# Altium schematic sheet D_SUB CONNECTOR.SchDoc("D_SUB CONNECTOR")
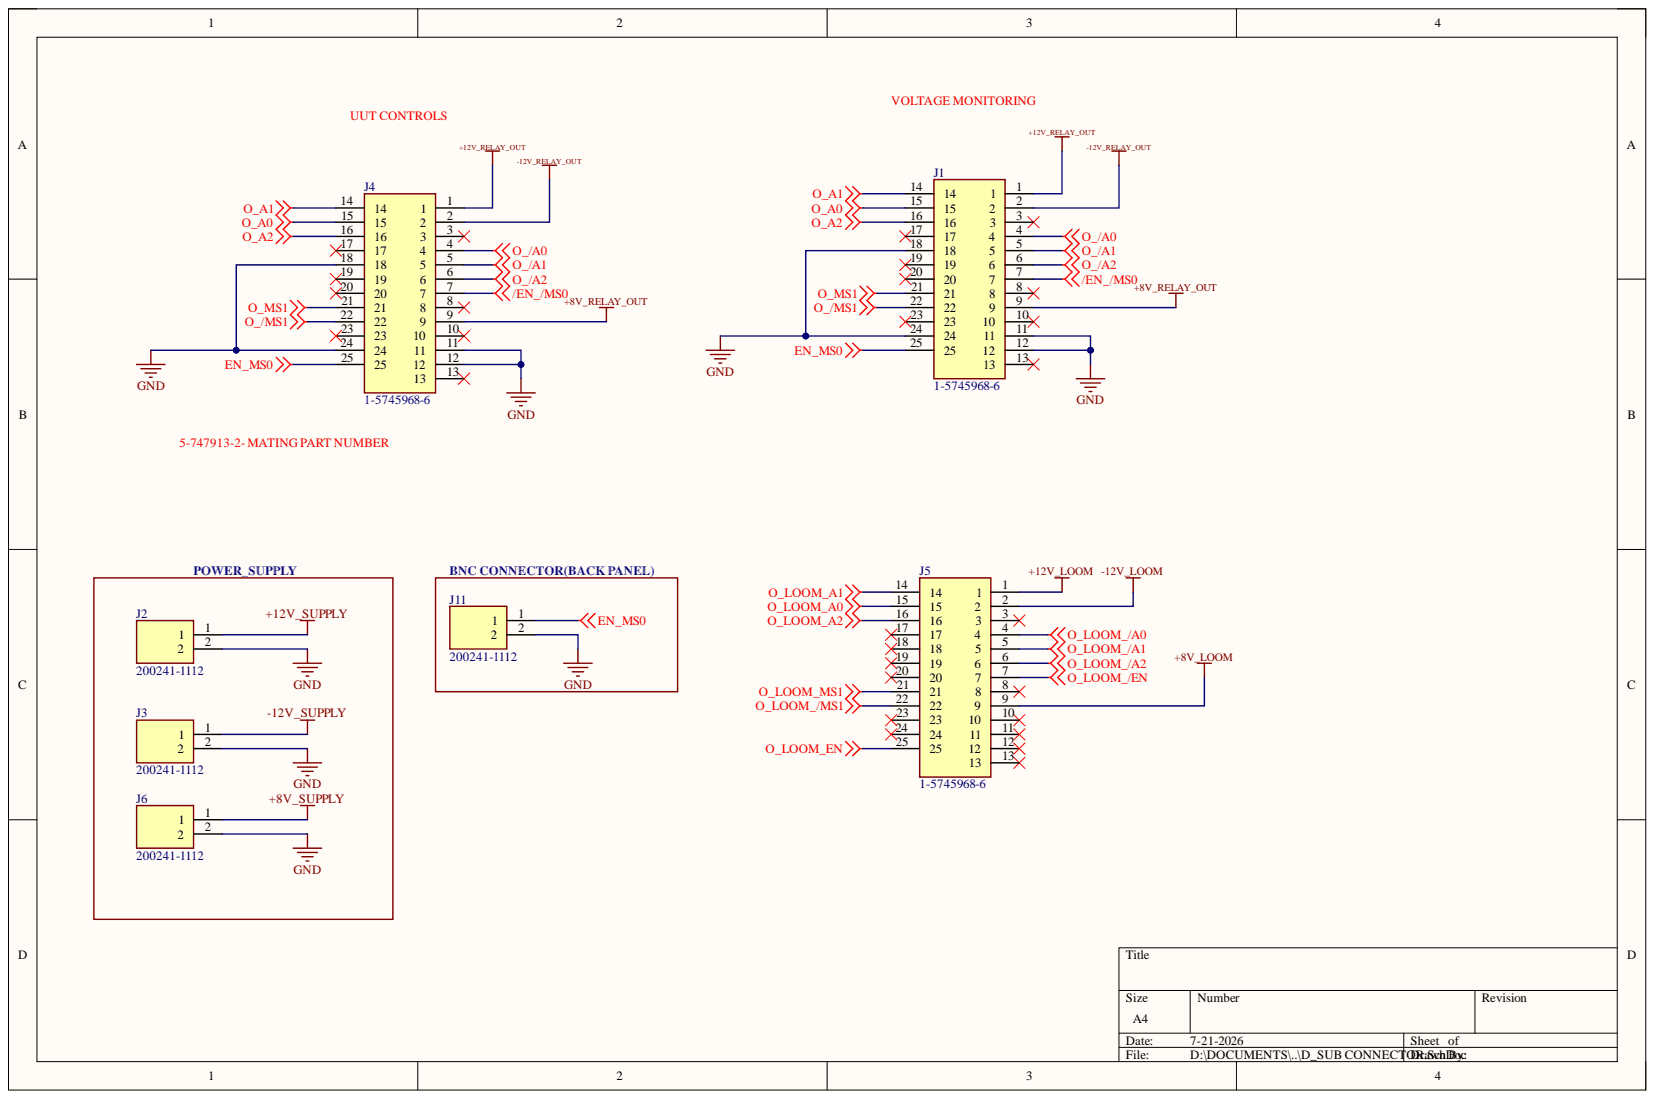
[diagram p0: D_SUB CONNECTOR.SchDoc]

## Parts
J1  1-5745968-6  [157459686]
J2  200241-1112  [2002411112]
J3  200241-1112  [2002411112]
J4  1-5745968-6  [157459686]
J5  1-5745968-6  [157459686]
J6  200241-1112  [2002411112]
J11  200241-1112  [2002411112]

## Nets
+8V_LOOM: J5.9
+8V_RELAY_OUT: J1.9 J4.9
+8V_SUPPLY: J6.1
+12V_LOOM: J5.1
+12V_RELAY_OUT: J1.1 J4.1
+12V_SUPPLY: J2.1
-12V_LOOM: J5.2
-12V_RELAY_OUT: J1.2 J4.2
-12V_SUPPLY: J3.1
/EN_/MS0: J1.7 J4.7
EN_MS0: J1.25 J4.25 J11.1
GND: J1.11 J1.12 J1.18 J1.24 J2.2 J3.2 J4.11 J4.12 J4.18 J4.24 J6.2 J11.2
O_/A0: J1.4 J4.4
O_/A1: J1.5 J4.5
O_/A2: J1.6 J4.6
O_/MS1: J1.22 J4.22
O_A0: J1.15 J4.15
O_A1: J1.14 J4.14
O_A2: J1.16 J4.16
O_LOOM_/A0: J5.4
O_LOOM_/A1: J5.5
O_LOOM_/A2: J5.6
O_LOOM_/EN: J5.7
O_LOOM_/MS1: J5.22
O_LOOM_A0: J5.15
O_LOOM_A1: J5.14
O_LOOM_A2: J5.16
O_LOOM_EN: J5.25
O_LOOM_MS1: J5.21
O_MS1: J1.21 J4.21

Not connected: J1 3 8 10 13 17 19 20 23; J4 3 8 10 13 17 19 20 23; J5 3 8 10 11 12 13 17 18 19 20 23 24

## Library data of the parts
J1 J4 J5 = 1-5745968-6: Plug, Board-to-Board, 25 Position, 2.77 mm [.109 in] Centerline, 2 Row, 3 Shell Size, Round, Standard Profile, AMPLIMITE HD-20, PCB D-Sub Connectors; Manufacturer_Name: TE Connectivity; Geometry.Height: 12.51mm; Component Kind: Standard; Datasheet Link: https://www.te.com/commerce/DocumentDelivery/DDEController?Action=srchrtrv&DocNm=5745968&DocType=Customer%20Drawing&DocLang=English&DocFormat=pdf&PartCntxt=1-5745968-6; Library Name: MAX10.SchLib; Manufacturer_Part_Number: 1-5745968-6; Mouser Part Number: 571-1-5745968-6; Mouser Price/Stock: https://www.mouser.co.uk/ProductDetail/TE-Connectivity/1-5745968-6?qs=FlGfrDooHc0q7uJ9UJm%252Bsw%3D%3D
J2 J3 J6 J11 = 200241-1112: MEGA-FIT V/T TOP LATCH ASSY TIN 2CKT BLK; Manufacturer_Name: Molex; Geometry.Height: 17.75mm; Component Kind: Standard; Datasheet Link: https://componentsearchengine.com/Datasheets/1/200241-1112.pdf; Manufacturer_Part_Number: 200241-1112; Mouser Part Number: 538-200241-1112; Mouser Price/Stock: https://www.mouser.co.uk/ProductDetail/Molex/200241-1112?qs=PzGy0jfpSMspIUQO9H%252Bd2w%3D%3D; Library Name: ANAND_LIB.SchLib
